# Altium schematic sheet LilyPad51822-00.SchDoc
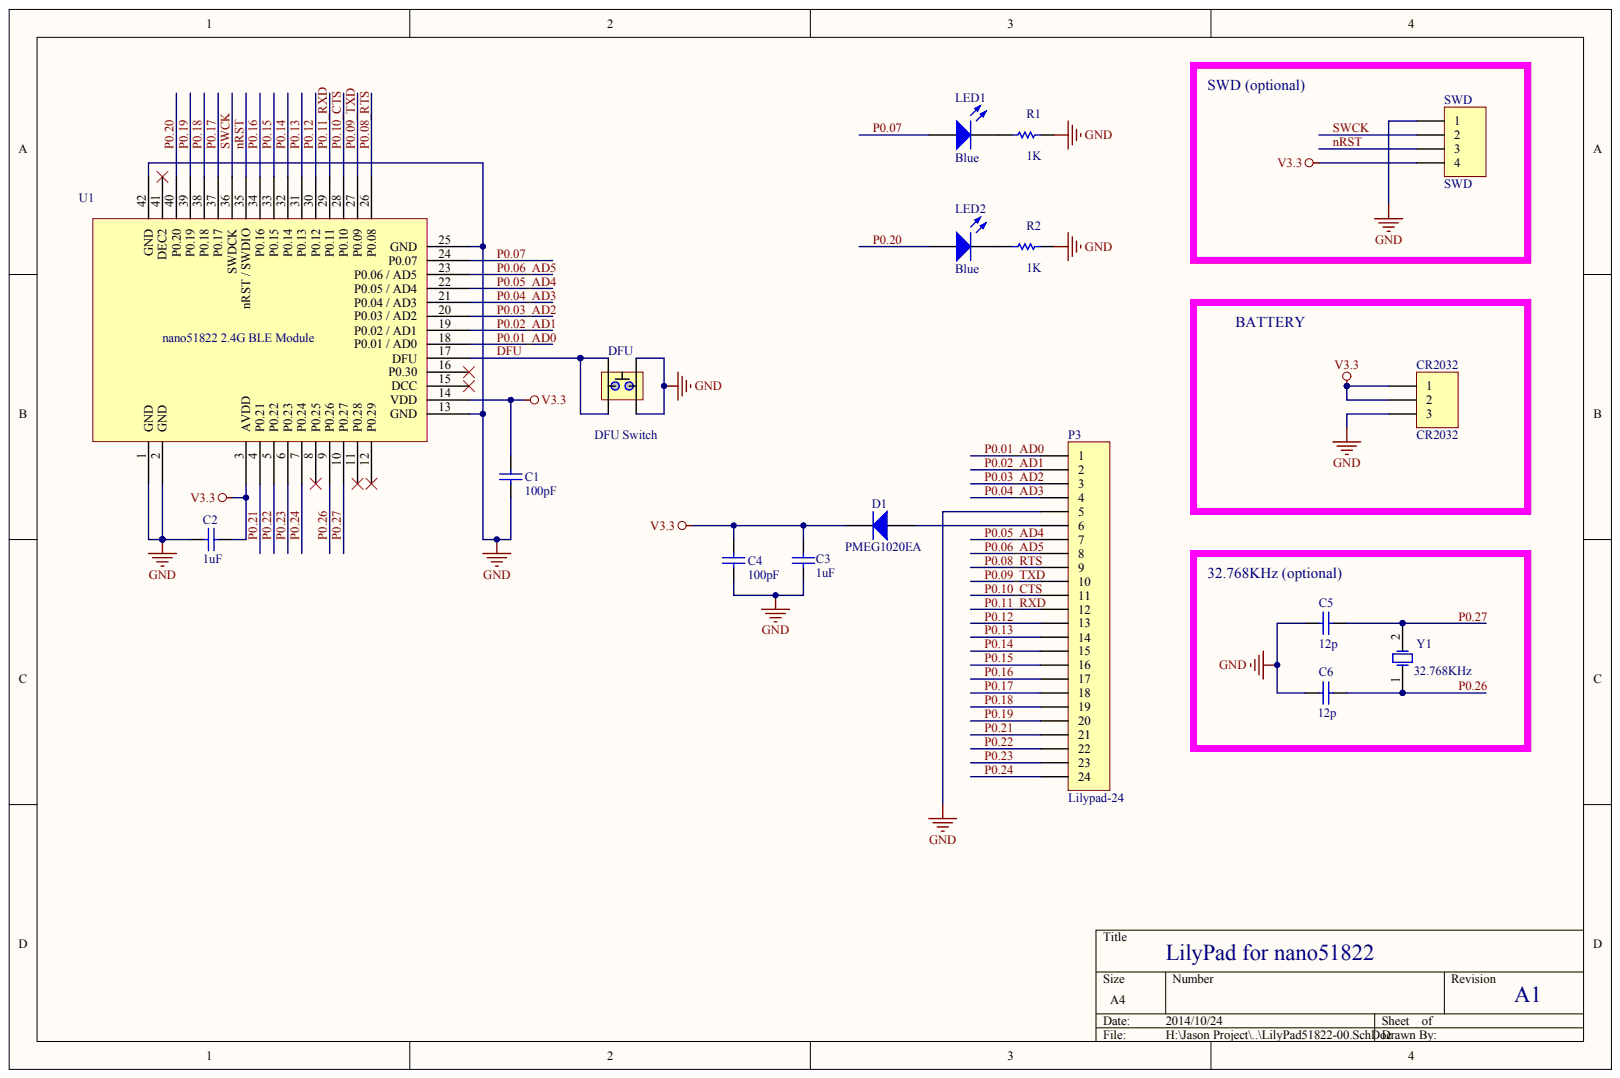
[diagram p0: LilyPad51822-00.SchDoc]

## Parts
C1  value 100pF  (hidden comment: Cap)
C2  value 1uF  (hidden comment: Cap)
C3  value 1uF  (hidden comment: Cap)
C4  value 100pF  (hidden comment: Cap)
C5  value 12p  (hidden comment: Cap Semi)
C6  value 12p  (hidden comment: Cap Semi)
CR2032  CR2032
D1  PMEG1020EA
DFU  DFU Switch
LED1  Blue
LED2  Blue
P3  Lilypad-24
R1  value 1K  (hidden comment: Res1)
R2  value 1K  (hidden comment: Res1)
SWD  SWD
U1  nano51822 2.4G BLE Module
Y1  32.768KHz

## Nets
GND: C1.1 C2.2 C3.2 C4.1 C5.2 C6.2 CR2032.3 DFU.1 DFU.2 P3.5 R1.2 R2.2 SWD.1 U1.1(GND) U1.2(GND) U1.13(GND) U1.25(GND) U1.42(GND)
V3.3: C1.2 C2.1 C3.1 C4.2 CR2032.1 CR2032.2 D1.2 SWD.4 U1.3(AVDD) U1.14(VDD)
DFU: DFU.3 DFU.4 U1.17(DFU)
NRST: SWD.3 U1.35(nRST_/_SWDIO)
P0.01_AD0: P3.1 U1.18(P0.01_/_AD0)
P0.02_AD1: P3.2 U1.19(P0.02_/_AD1)
P0.03_AD2: P3.3 U1.20(P0.03_/_AD2)
P0.04_AD3: P3.4 U1.21(P0.04_/_AD3)
P0.05_AD4: P3.7 U1.22(P0.05_/_AD4)
P0.06_AD5: P3.8 U1.23(P0.06_/_AD5)
P0.07: LED1.1 U1.24(P0.07)
P0.08_RTS: P3.9 U1.26(P0.08)
P0.09_TXD: P3.10 U1.27(P0.09)
P0.10_CTS: P3.11 U1.28(P0.10)
P0.11_RXD: P3.12 U1.29(P0.11)
P0.12: P3.13 U1.30(P0.12)
P0.13: P3.14 U1.31(P0.13)
P0.14: P3.15 U1.32(P0.14)
P0.15: P3.16 U1.33(P0.15)
P0.16: P3.17 U1.34(P0.16)
P0.17: P3.18 U1.37(P0.17)
P0.18: P3.19 U1.38(P0.18)
P0.19: P3.20 U1.39(P0.19)
P0.20: LED2.1 U1.40(P0.20)
P0.21: P3.21 U1.4(P0.21)
P0.22: P3.22 U1.5(P0.22)
P0.23: P3.23 U1.6(P0.23)
P0.24: P3.24 U1.7(P0.24)
P0.26: C6.1 U1.9(P0.26) Y1.1
P0.27: C5.1 U1.10(P0.27) Y1.2
SWCK: SWD.2 U1.36(SWDCK)
NetD1_1: D1.1 P3.6
NetLED1_2: LED1.2 R1.1
NetLED2_2: LED2.2 R2.1

Not connected: U1 8(P0.25) 11(P0.28) 12(P0.29) 15(DCC) 16(P0.30) 41(DEC2)

## Library data of the parts
C1-C6 CR2032 D1 DFU LED1 LED2 P3 R1 R2 SWD U1 Y1 = (no symbol name)
  C1-C4: Published: 8-Jun-2000; LatestRevisionDate: 17-Jul-2002; LatestRevisionNote: Re-released for DXP Platform.; PackageReference: RAD-0.3; PackageDescription: Radial Cap, Thru-Hole; 2 Leads; 0.3 in Pin Spacing
  C5 C6: Published: 8-Jun-2000; LatestRevisionDate: 29-May-2009; LatestRevisionNote: IPC-7351 Footprint Added.; PackageReference: C1206; PackageDescription: Chip Capacitor
  CR2032 P3 SWD: LatestRevisionDate: 17-Jul-2002; LatestRevisionNote: Re-released for DXP Platform.
  D1: Published: 8-Jun-2000; LatestRevisionDate: 17-Jul-2002; LatestRevisionNote: Re-released for DXP Platform.; PackageVersion: Sep-1996; PackageReference: SMC; PackageDescription: DO-214-AB/SMC; 2 C-Bend Leads; Body 7.9 x 5.9 mm, inc. leads (LxW); Code_JEDEC: DO-214-AB
  LED1 LED2: Published: 8-Jun-2000; LatestRevisionDate: 17-Dec-2003; LatestRevisionNote: PCB Footprint replaced.; DatasheetVersion: 16-Apr-2002; PackageVersion: 16-Apr-2002; PackageReference: 3.5X2.8X1.9; PackageDescription: SM LED; 2 C-Bend Leads; Body 3.5 x 2.8 x 1.9 mm, inc leads (LxWxH typ)
  R1 R2: Published: 8-Jun-2000; LatestRevisionDate: 17-Jul-2002; LatestRevisionNote: Re-released for DXP Platform.; PackageReference: AXIAL-0.3; PackageDescription: Axial Device, Thru-Hole; 2 Leads; 0.3 in Pin Spacing
  Y1: Published: 8-Jun-2000; LatestRevisionDate: 17-Jul-2002; LatestRevisionNote: Re-released for DXP Platform.; PackageReference: R38; PackageDescription: Crystal, Thru-Hole; 2 Leads; Body 3.1 x 8.2 mm (Dia.xH)
  C1-C6 CR2032 D1 LED1 LED2 P3 R1 R2 SWD Y1: Publisher: Altium Limited
